# Altium schematic sheet Main.SchDoc
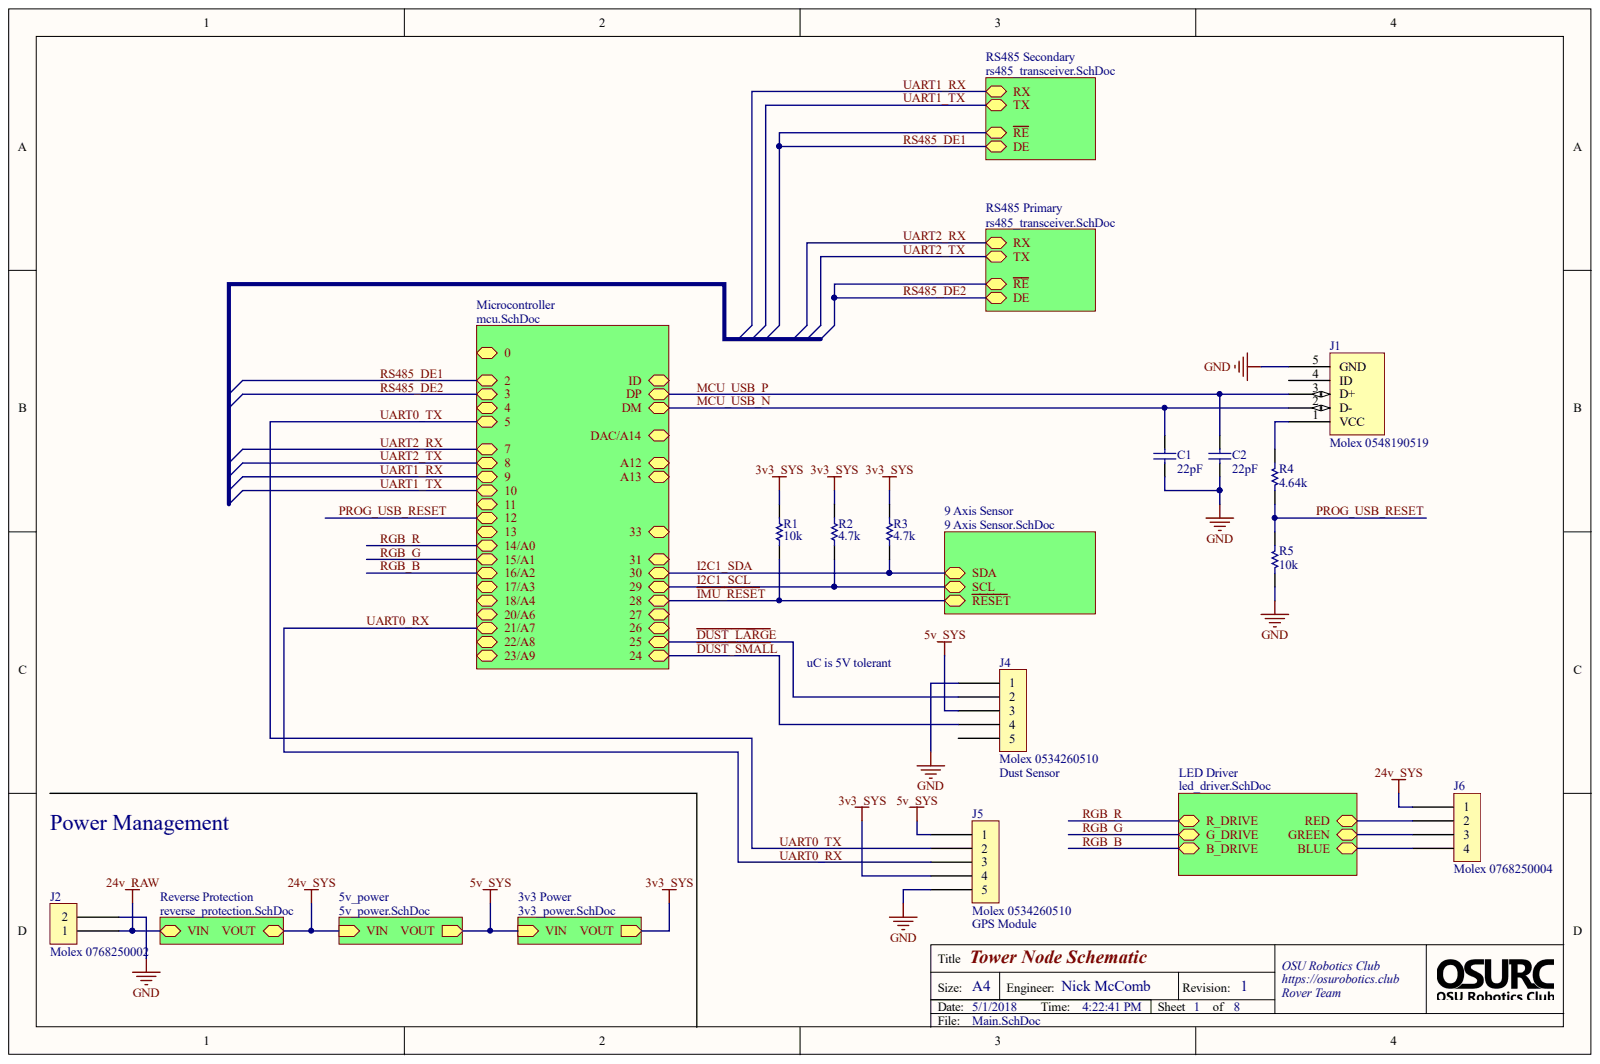
[diagram p0: Main.SchDoc]

## Parts
C1  Capacitance: 22pF  [CAPC2013X100X30LL20]  (hidden comment: Murata GRM21A5C2E220JW01D)
C2  Capacitance: 22pF  [CAPC2013X100X30LL20]  (hidden comment: Murata GRM21A5C2E220JW01D)
J1  Molex 0548190519  Manufacturer Part Number: 0548190519  [PCB-2328442-1]
J2  Molex 0768250002  Manufacturer Part Number: 0768250002  [PCB-vsyw3ck08wplnfxoqa7k-1]
J4  Molex 0534260510  Manufacturer Part Number: 0534260510  [PCB-6sinxzn8930o7i7qfdl8-1]
J5  Molex 0534260510  Manufacturer Part Number: 0534260510  [PCB-6sinxzn8930o7i7qfdl8-1]
J6  Molex 0768250004  [PCB-n62yc6w6j91xslt67wd4-1]
R1  Resistance: 10k  [PCB-9ahxsq8gddjqcnfjmojj-1]  (hidden comment: Stackpole Electronics RMCF0603FT10K0)
R2  Resistance: 4.7k  [PCB-nun9d6hgfo6atovtzbqg-1]  (hidden comment: Stackpole Electronics RMCF0603FT4K70)
R3  Resistance: 4.7k  [PCB-nun9d6hgfo6atovtzbqg-1]  (hidden comment: Stackpole Electronics RMCF0603FT4K70)
R4  Resistance: 4.64k  [PCB-9ahxsq8gddjqcnfjmojj-1]  (hidden comment: Stackpole Electronics RMCF0603FT4K64)
R5  Resistance: 10k  [PCB-9ahxsq8gddjqcnfjmojj-1]  (hidden comment: Stackpole Electronics RMCF0603FT10K0)

## Nets
3V3_SYS: J5.4 R1.2 R2.2 R3.2
5V_SYS: J4.3 J5.1
24V_RAW: J2.3
24V_SYS: J6.1
GND: C1.1 C2.1 J1.5(GND) J2.4 J4.1 J5.5 R5.2
~{DUST_LAR}~{GE}: J4.2
~{DUST_SMALL}: J4.4
I2C1_SCL: R2.1
I2C1_SDA: R3.1
~{IMU_RESET}: R1.1
MCU_USB_N: C1.2 J1.2(D-)
MCU_USB_P: C2.2 J1.3(D+)
PROG_USB_RESET: R4.1 R5.1
UART0_RX: J5.3
UART0_TX: J5.2
NetJ1_1: J1.1(VCC) R4.2
NetJ1_4: J1.4(ID)
NetJ4_5: J4.5
NetJ6_2: J6.2
NetJ6_3: J6.3
NetJ6_4: J6.4
0:
4:
11:
13:
17/A3:
18/A4:
20/A6:
22/A8:
23/A9:
26:
27:
31:
33:
A12:
A13:
DAC/A14:
ID:
N00030:
RGB_B:
RGB_G:
RGB_R:
RS485_DE1:
RS485_DE2:
UART1_RX:
UART1_TX:
UART2_RX:
UART2_TX:

## Library data of the parts
C1 C2 = CMP-120985-2: CAP CER 22PF 250V 5% NP0 0805; Dielectric Characteristic: C0G/NP0; Lead-Free Status: Lead Free; Voltage Rating (DC): 250.0 V; Capacitance Tolerance: ±5%; Component Kind: Standard; Library Name: CommunityVault; OctopartID: 0076c85e5a45dfb1; Octopart Url: https://octopart.com/grm21a5c2e220jw01d-murata-19038037; Packaging: Cut Tape (CT); REACH SVHC Compliance: No SVHC; RoHS: Compliant; Size-Length: 0.002 m; Size-Thickness: 0.001 m; Size-Width: 0.00125 m
J1 = CMP-2328442-3: Conn Mini USB Type B F 5 POS 1.6mm Solder RA Thru-Hole 5 Terminal 1 Port Tray; Body Orientation: Right Angle; Gender: RCP; Number of Contacts: 5; CiivaID: 2328442; Ciiva URL: https://ciiva.com/part/0548190519-2328442; Component Kind: Standard; Library Name: CommunityVault; Mounting: Through Hole; Packaging: Trays; Pitch: 1.6 mm; Termination Method: Solder; Type: USB
J2 = CMP-78d7b2319172a710-4: Mega-Fit Ra Hdr Assy 2 Ckt Tin; Component Kind: Standard; Library Name: ProjectLibrary; Lifecycle Status: Not Listed by Manufacturer; OctopartID: 78d7b2319172a710; Octopart URL: http://octopart.com/0768250002-molex-65850563; RoHS: Compliant
J4 J5 = CMP-014cc8a852cade8b-2: Conn Shrouded Header HDR 5 POS 2.5mm Solder RA Thru-Hole Mini-Lock™ Tray; Gender: Male; Component Kind: Standard; Library Name: CommunityVault; Lifecycle Status: Not Listed by Manufacturer; Mounting Style: Solder; OctopartID: 014cc8a852cade8b; Octopart URL: http://octopart.com/0534260510-molex-15628000; Packaging: Tray; RoHS: Compliant
J6 = CMP-ff94357216382053-1: Mega-Fit Vert Hdr Assy 4 Ckt Tin; Component Kind: Standard; Library Name: CommunityVault; RoHS: Compliant
R1 R5 = CMP-02dfcaf00d3bdd18-2: RMCF Series 0603 Case 10 kOhm ±1 % ±100 ppm/°C SMT Thick Film Chip Resistor; Lead-Free Status: Lead Free; Power Rating: 100 mW; Resistance Tolerance: ±1%; Case/Package: 0603; Component Kind: Standard; Library Name: CommunityVault; Manufacturer Part Number: RMCF0603FT10K0; Mounting Style: Surface Mount; OctopartID: 02dfcaf00d3bdd18; Octopart URL: http://octopart.com/rmcf0603ft10k0-stackpole+electronics-19212930; Packaging: Tape & Reel (TR); RoHS: Compliant
R2 R3 = CMP-011ec9310fadded9-1: Res Thick Film 0603 4.7K Ohm 1% 0.1W(1/10W) ???}100ppm/??? Molded SMD Automotive Paper T/R; Lead-Free Status: Lead Free; Power Rating: 100 mW; Case/Package: 0603; Component Kind: Standard; Library Name: CommunityVault; Manufacturer Part Number: RMCF0603FT4K70; Mounting Style: Surface Mount; OctopartID: 011ec9310fadded9; Octopart URL: https://octopart.com/rmcf0603ft4k70-stackpole+electronics-19213269; Packaging: Tape & Reel (TR); Resistance Tolerance: ±1%; RoHS: Compliant
R4 = CMP-507a98555ec88fbd-1: Res Thick Film 0603 4.64K Ohm 1% 1/10W ±100ppm/°C Molded SMD SMD Paper T/R; Power Rating: 100 mW; Case/Package: 0603; Component Kind: Standard; Library Name: CommunityVault; Manufacturer Part Number: RMCF0603FT4K64; Mounting Style: Surface Mount; OctopartID: 507a98555ec88fbd; Octopart URL: https://octopart.com/rmcf0603ft4k64-stackpole+electronics-19213268; Packaging: Tape & Reel (TR); Resistance Tolerance: ±1%; RoHS: Compliant
